# Altium schematic sheet rpi.SchDoc
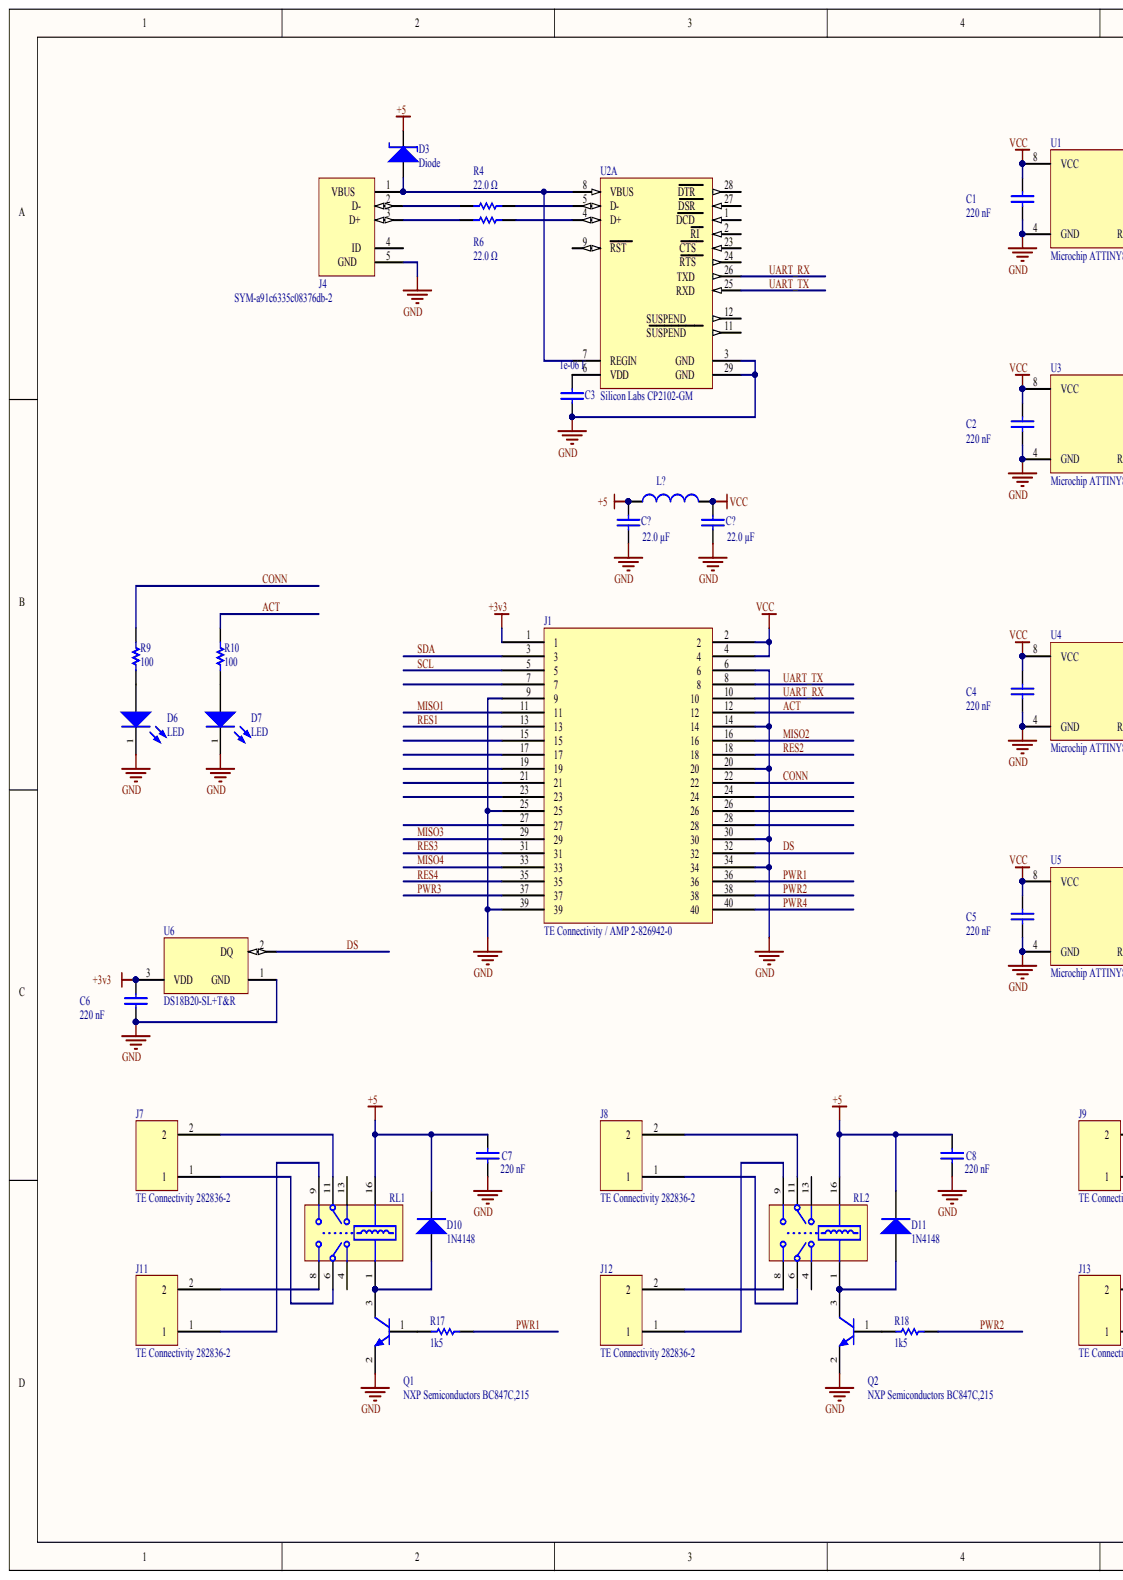
[diagram p0: rpi.SchDoc, left]
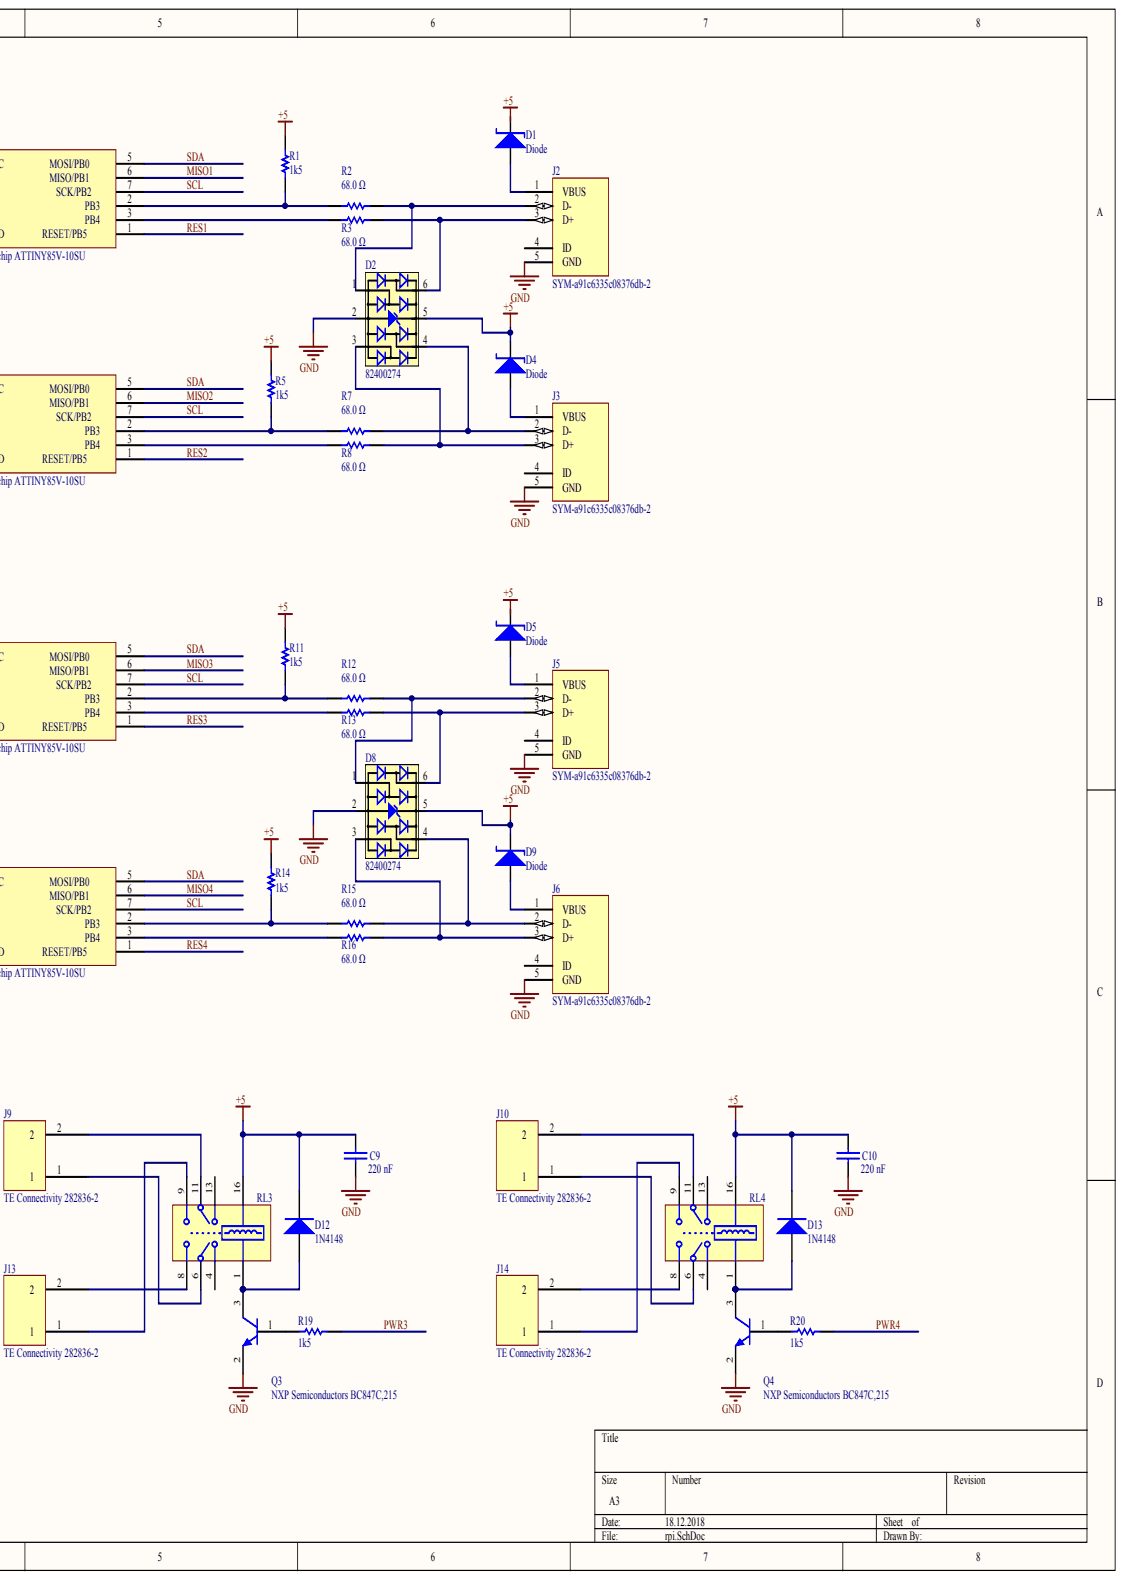
[diagram p1: rpi.SchDoc, right]

## Parts
C1  Capacitance: 220 nF  [0603C_B]  (hidden comment: Murata GRM188R71E224KA88D)
C2  Capacitance: 220 nF  [0603C_B]  (hidden comment: Murata GRM188R71E224KA88D)
C3  Capacitance: 1e-06 F  [CAPC1608X90X35ML10T15]  (hidden comment: Murata GRM188R71C105KA12D)
C4  Capacitance: 220 nF  [0603C_B]  (hidden comment: Murata GRM188R71E224KA88D)
C5  Capacitance: 220 nF  [0603C_B]  (hidden comment: Murata GRM188R71E224KA88D)
C6  Capacitance: 220 nF  [0603C_B]  (hidden comment: Murata GRM188R71E224KA88D)
C7  Capacitance: 220 nF  [0603C_B]  (hidden comment: Murata GRM188R71E224KA88D)
C8  Capacitance: 220 nF  [0603C_B]  (hidden comment: Murata GRM188R71E224KA88D)
C9  Capacitance: 220 nF  [0603C_B]  (hidden comment: Murata GRM188R71E224KA88D)
C10  Capacitance: 220 nF  [0603C_B]  (hidden comment: Murata GRM188R71E224KA88D)
C?  Capacitance: 22.0 µF  [0805_A]  (hidden comment: Murata GRM21BR60J226ME39L)
C?  Capacitance: 22.0 µF  [0805_A]  (hidden comment: Murata GRM21BR60J226ME39L)
D1  Diode  [PCB-vjq2rjjsup9nxnsehq5y-1]
D2  82400274  [SC70-6L]
D3  Diode  [PCB-vjq2rjjsup9nxnsehq5y-1]
D4  Diode  [PCB-vjq2rjjsup9nxnsehq5y-1]
D5  Diode  [PCB-vjq2rjjsup9nxnsehq5y-1]
D6  LED  [PCB-gr2jy36d8nx0qmaftz6p-1]
D7  LED  [PCB-gr2jy36d8nx0qmaftz6p-1]
D8  82400274  [SC70-6L]
D9  Diode  [PCB-vjq2rjjsup9nxnsehq5y-1]
D10  1N4148  [PCB-1N4148W-7-F]
D11  1N4148  [PCB-1N4148W-7-F]
D12  1N4148  [PCB-1N4148W-7-F]
D13  1N4148  [PCB-1N4148W-7-F]
J1  TE Connectivity / AMP 2-826942-0  [PCB-06fujmgdlxhtirs4uei8-1]
J2  SYM-a91c6335c08376db-2  [PCB-c5b7ftra52io2x53bzmw-1]
J3  SYM-a91c6335c08376db-2  [PCB-c5b7ftra52io2x53bzmw-1]
J4  SYM-a91c6335c08376db-2  [PCB-c5b7ftra52io2x53bzmw-1]
J5  SYM-a91c6335c08376db-2  [PCB-c5b7ftra52io2x53bzmw-1]
J6  SYM-a91c6335c08376db-2  [PCB-c5b7ftra52io2x53bzmw-1]
J7  TE Connectivity 282836-2  Manufacturer Part Number: 282836-2  [PCB-1gi00udnwlns1fthuchu-1]
J8  TE Connectivity 282836-2  Manufacturer Part Number: 282836-2  [PCB-1gi00udnwlns1fthuchu-1]
J9  TE Connectivity 282836-2  Manufacturer Part Number: 282836-2  [PCB-1gi00udnwlns1fthuchu-1]
J10  TE Connectivity 282836-2  Manufacturer Part Number: 282836-2  [PCB-1gi00udnwlns1fthuchu-1]
J11  TE Connectivity 282836-2  Manufacturer Part Number: 282836-2  [PCB-1gi00udnwlns1fthuchu-1]
J12  TE Connectivity 282836-2  Manufacturer Part Number: 282836-2  [PCB-1gi00udnwlns1fthuchu-1]
J13  TE Connectivity 282836-2  Manufacturer Part Number: 282836-2  [PCB-1gi00udnwlns1fthuchu-1]
J14  TE Connectivity 282836-2  Manufacturer Part Number: 282836-2  [PCB-1gi00udnwlns1fthuchu-1]
L?  Murata LQM21NN2R2K10D  [PCB-6xavmov8f9jbkmhuz9jz-1]  (value not drawn)
Q1  NXP Semiconductors BC847C,215  [PCB-p8khi1w6gntrmsp9bbyg-1]
Q2  NXP Semiconductors BC847C,215  [PCB-p8khi1w6gntrmsp9bbyg-1]
Q3  NXP Semiconductors BC847C,215  [PCB-p8khi1w6gntrmsp9bbyg-1]
Q4  NXP Semiconductors BC847C,215  [PCB-p8khi1w6gntrmsp9bbyg-1]
R1  1k5  [PCB-9cf7z3ce0dktpf6ltksy-1]
R2  Resistance: 68.0 Ω  [RESC1609X50X30ML10T20]  (hidden comment: Vishay Dale CRCW060368R0FKEA)
R3  Resistance: 68.0 Ω  [RESC1609X50X30ML10T20]  (hidden comment: Vishay Dale CRCW060368R0FKEA)
R4  Resistance: 22.0 Ω  [RESC1609X50X30ML10T20]  (hidden comment: Vishay Dale CRCW060322R0JNEA)
R5  1k5  [PCB-9cf7z3ce0dktpf6ltksy-1]
R6  Resistance: 22.0 Ω  [RESC1609X50X30ML10T20]  (hidden comment: Vishay Dale CRCW060322R0JNEA)
R7  Resistance: 68.0 Ω  [RESC1609X50X30ML10T20]  (hidden comment: Vishay Dale CRCW060368R0FKEA)
R8  Resistance: 68.0 Ω  [RESC1609X50X30ML10T20]  (hidden comment: Vishay Dale CRCW060368R0FKEA)
R9  100  [RESC1609X55X28LL05T18]
R10  100  [RESC1609X55X28LL05T18]
R11  1k5  [PCB-9cf7z3ce0dktpf6ltksy-1]
R12  Resistance: 68.0 Ω  [RESC1609X50X30ML10T20]  (hidden comment: Vishay Dale CRCW060368R0FKEA)
R13  Resistance: 68.0 Ω  [RESC1609X50X30ML10T20]  (hidden comment: Vishay Dale CRCW060368R0FKEA)
R14  1k5  [PCB-9cf7z3ce0dktpf6ltksy-1]
R15  Resistance: 68.0 Ω  [RESC1609X50X30ML10T20]  (hidden comment: Vishay Dale CRCW060368R0FKEA)
R16  Resistance: 68.0 Ω  [RESC1609X50X30ML10T20]  (hidden comment: Vishay Dale CRCW060368R0FKEA)
R17  1k5  [PCB-9cf7z3ce0dktpf6ltksy-1]
R18  1k5  [PCB-9cf7z3ce0dktpf6ltksy-1]
R19  1k5  [PCB-9cf7z3ce0dktpf6ltksy-1]
R20  1k5  [PCB-9cf7z3ce0dktpf6ltksy-1]
RL1  Omron G5V-2-DC12  [PCB-xh0ouwlelej4hns4evo0-1]  (value not drawn)
RL2  Omron G5V-2-DC12  [PCB-xh0ouwlelej4hns4evo0-1]  (value not drawn)
RL3  Omron G5V-2-DC12  [PCB-xh0ouwlelej4hns4evo0-1]  (value not drawn)
RL4  Omron G5V-2-DC12  [PCB-xh0ouwlelej4hns4evo0-1]  (value not drawn)
U1  Microchip ATTINY85V-10SU  Manufacturer Part Number: ATTINY85V-10SU  [SOP8_troughBoard]
U2  Silicon Labs CP2102-GM  Manufacturer Part Number: CP2102-GM  [PCB-r2q4wj0b96jlqp92htuq-1]  (part A)
U3  Microchip ATTINY85V-10SU  Manufacturer Part Number: ATTINY85V-10SU  [SOP8_troughBoard]
U4  Microchip ATTINY85V-10SU  Manufacturer Part Number: ATTINY85V-10SU  [SOP8_troughBoard]
U5  Microchip ATTINY85V-10SU  Manufacturer Part Number: ATTINY85V-10SU  [SOP8_troughBoard]
U6  DS18B20-SL+T&R  [Q3+1]

## Nets
+3V3: C6.1 J1.1 U6.3
+5: C7.1 C8.1 C9.1 C10.1 C?.1 D2.5 D3.2 D4.2 D8.5 D9.2 D10.2 D11.2 D12.2 D13.2 L?.1 R1.2 R5.2 R11.2 R14.2 RL1.16 RL2.16 RL3.16 RL4.16
GND: C1.2 C2.2 C3.1 C4.2 C5.2 C6.2 C7.2 C8.2 C9.2 C10.2 C?.2 D2.2 D6.1 D7.1 D8.2 J1.6 J1.9 J1.14 J1.20 J1.25 J1.30 J1.34 J1.39 J2.5(GND) J3.5(GND) J4.5(GND) J5.5(GND) J6.5(GND) Q1.2 Q2.2 Q3.2 Q4.2 U1.4(GND) U2.3(GND) U2.29(GND) U3.4(GND) U4.4(GND) U5.4(GND) U6.1
VCC: C1.1 C2.1 C4.1 C5.1 C?.1 J1.2 J1.4 L?.2 U1.8(VCC) U3.8(VCC) U4.8(VCC) U5.8(VCC)
ACT: J1.12 R10.2
CONN: J1.22 R9.2
DS: J1.32 U6.2
MISO1: J1.11 U1.6
MISO2: J1.16 U3.6
MISO3: J1.29 U4.6
MISO4: J1.33 U5.6
PWR1: J1.36 R17.1
PWR2: J1.38 R18.1
PWR3: J1.37 R19.1
PWR4: J1.40 R20.1
RES1: J1.13 U1.1
RES2: J1.18 U3.1
RES3: J1.31 U4.1
RES4: J1.35 U5.1
SCL: J1.5 U1.7 U3.7 U4.7 U5.7
SDA: J1.3 U1.5 U3.5 U4.5 U5.5
UART_RX: J1.10 U2.26(TXD)
UART_TX: J1.8 U2.25(RXD)
NetC3_2: C3.2 U2.6(VDD)
NetD1_1: D1.1 J2.1(VBUS)
NetD2_1: D2.1 J2.2(D-) R2.2
NetD2_3: D2.3 J3.3(D+) R8.2
NetD2_4: D2.4 J3.2(D-) R7.2
NetD2_6: D2.6 J2.3(D+) R3.2
NetD3_1: D3.1 J4.1(VBUS) U2.7(REGIN) U2.8(VBUS)
NetD4_1: D4.1 J3.1(VBUS)
NetD5_1: D5.1 J5.1(VBUS)
NetD6_2: D6.2 R9.1
NetD7_2: D7.2 R10.1
NetD8_1: D8.1 J5.2(D-) R12.2
NetD8_3: D8.3 J6.3(D+) R16.2
NetD8_4: D8.4 J6.2(D-) R15.2
NetD8_6: D8.6 J5.3(D+) R13.2
NetD9_1: D9.1 J6.1(VBUS)
NetD10_1: D10.1 Q1.3 RL1.1
NetD11_1: D11.1 Q2.3 RL2.1
NetD12_1: D12.1 Q3.3 RL3.1
NetD13_1: D13.1 Q4.3 RL4.1
NetJ4_2: J4.2(D-) R4.1
NetJ4_3: J4.3(D+) R6.1
NetJ7_1: J7.1 RL1.6
NetJ7_2: J7.2 RL1.11
NetJ8_1: J8.1 RL2.6
NetJ8_2: J8.2 RL2.11
NetJ9_1: J9.1 RL3.6
NetJ9_2: J9.2 RL3.11
NetJ10_1: J10.1 RL4.6
NetJ10_2: J10.2 RL4.11
NetJ11_1: J11.1 RL1.9
NetJ11_2: J11.2 RL1.8
NetJ12_1: J12.1 RL2.9
NetJ12_2: J12.2 RL2.8
NetJ13_1: J13.1 RL3.9
NetJ13_2: J13.2 RL3.8
NetJ14_1: J14.1 RL4.9
NetJ14_2: J14.2 RL4.8
NetQ1_1: Q1.1 R17.2
NetQ2_1: Q2.1 R18.2
NetQ3_1: Q3.1 R19.2
NetQ4_1: Q4.1 R20.2
NetR1_1: R1.1 R2.1 U1.2(PB3)
NetR3_1: R3.1 U1.3(PB4)
NetR4_2: R4.2 U2.5(D-)
NetR5_1: R5.1 R7.1 U3.2(PB3)
NetR6_2: R6.2 U2.4(D+)
NetR8_1: R8.1 U3.3(PB4)
NetR11_1: R11.1 R12.1 U4.2(PB3)
NetR13_1: R13.1 U4.3(PB4)
NetR14_1: R14.1 R15.1 U5.2(PB3)
NetR16_1: R16.1 U5.3(PB4)

Not connected: D1 2; D5 2; J1 7 15 17 19 21 23 24 26 27 28; J2 4(ID); J3 4(ID); J4 4(ID); J5 4(ID); J6 4(ID); RL1 4 13; RL2 4 13; RL3 4 13; RL4 4 13; U2 1(DCD) 2(RI) 9(RST) 11 12 23(CTS) 24(RTS) 27(DSR) 28(DTR)

## Library data of the parts
C1 C2 C4-C10 = CMP-19299718-5: 0603 0.22 uF 25 V ±10% Tolerance X7R SMT Multilayer Ceramic Capacitor; Case/Package (SI): 1608; Lead-Free Status: Lead Free; Voltage Rating (DC): 25.0 V; Capacitance Tolerance: ±10%; Case/Package: 0603, 1608; Component Kind: Standard; Dielectric Characteristic: X7R; Library Name: CommunityVault; Lifecycle Status: Active; Manufacturer Part Number: GRM188R71E224KA88D; Mounting Style: Surface Mount; Number of Pins: 2; OctopartID: 915b5852b5104e9c; Octopart Url: http://octopart.com/grm188r71e224ka88d-murata-196909; Packaging: Cut Tape (CT), Tape & Reel (TR); REACH SVHC Compliance: No SVHC; RoHS: Compliant; Seller Landing  Url: https://octopart-clicks.com/click/track?country=US&ak=8157f166&sig=0b4b672&sid=1106&ppid=196909&vpid=21236095&ct=offers; Size-Height: 800 µm; Size-Length: 1.60 mm; Size-Thickness: 800 µm; Size-Width: 800 µm
C3 = CMP-121260-3: CAP CER 1UF 16V 10% X7R 0603; Case/Package (SI): 1608; Lead-Free Status: Lead Free; Voltage Rating (DC): 16.0 V; Capacitance Tolerance: ±10%; Component Kind: Standard; Dielectric Characteristic: X7R; Library Name: CommunityVault; Lifecycle Status: Active; Manufacturer Part Number: GRM188R71C105KA12D; Mounting Style: Surface Mount; Number of Pins: 2; OctopartID: 978b5e82b1a1484c; Octopart Url: https://octopart.com/grm188r71c105ka12d-murata-197452; REACH SVHC Compliance: No SVHC; RoHS: Compliant; Size-Height: 0.0008 m; Size-Length: 0.0016 m; Size-Thickness: 0.0008 m; Size-Width: 0.0008 m
C? C? = CMP-19299736-5: Multilayer Ceramic Capacitors MLCC Surface Mount 0805 22uF 6.3 Volts X5R 20%; Voltage Rating (DC): 6.30 V; Component Kind: Standard; Library Name: CommunityVault; Number of Pins: 2; Packaging: Cut Tape (CT), Tape & Reel (TR)
D1 D3-D5 D9 = CMP-fb98311b90f6245f-5: Diode Schottky SOD-123; Component Kind: Standard; Library Name: CommunityVault; Octopart URL: http://octopart.com/ss14fl-fairchild+semiconductor-59330056
D2 D8 = CMP-1038096-2: TVS Diode WE-TVS-HS, VRWM=5.0V; Component Kind: Standard; Library Name: CommunityVault; Manufacturer Part Number: 82400274; Number of Pins: 6; OctopartID: 26cceed38cbbf90b; Octopart Url: https://octopart.com/82400274-w%C3%BCrth+elektronik-18190097; REACH SVHC Compliance: No SVHC; RoHS: Compliant
D6 D7 = CMP-1544855-6: LED; SMD; 0603; red; 18-180mcd; 1.6x0.8x0.8mm; 130°; 1.6÷2.4V; 20mA; Manufacturer Part Number: LTST-C190KRKT; Case/Package (SI): 1608; Forward Voltage: 2.00 V; Lead-Free Status: Lead Free; Lens Type: Non-Diffused; Luminous Intensity: 54.0 mcd; Wavelength: 639 nm; Case/Package: 0603; Color: Red; Component Kind: Standard; Library Name: CommunityVault; Mounting Style: Surface Mount, Vertical; OctopartID: 1e52d7505f31c195; Operating Temperature: 85.0 °C (max); Packaging: Tape & Reel (TR), Cut Tape (CT); RoHS: Compliant; Size-Length: 1.60 mm; Size-Width: 800 µm; Viewing Angle: 130 °
D10-D13 = CMP-791fb3587d52a6d8-18: Fast Switching Diode, 100V, 400mW, Surface Mount, SOD-123; Manufacturer Part Number: 1N4148W-7-F; Current Rating: 150 mA; Output Current: 300mA (max); Case/Package: SOD-123; Component Kind: Standard; Datasheet PDF: https://www.diodes.com/assets/Datasheets/ds30086.pdf; Library Name: CommunityVault; Manufacturer PN: 1N4148W-7-F; Mounting Style: Surface Mount; OctopartID: 791fb3587d52a6d8; Octopart URL: https://octopart.com/1n4148w-7-f-diodes+inc.-55386411; RoHS: Compliant; Voltage Rating (DC): 100V
J1 = CMP-ccc407be18a11303-7: Conn Unshrouded Header HDR 40 POS 2.54mm Solder ST Thru-Hole Carton; Component Kind: Standard; Library Name: CommunityVault
J2-J6 = CMP-20438115-17: Horizontal SMT Type B Version 2.0 MicroUSB Connector Socket; 30 V ac; 3A WR-COM; Component Kind: Standard; Library Name: CommunityVault; RoHS: Compliant
J7-J14 = CMP-369bfdc835bbe95c-11: Connector, Terminal Block; Wire Receptacle; 2 Pos.; 16-30 AWG; 0.197 in. CL; Sid; Current Rating: 13.5 A; ELV Compliant: Compliant; Lead-Free Status: Lead Free; Number of Contacts: 2; Pin Pitch: 5.00 mm; Voltage Rating (AC): 250 V; Wire Gauge: 15.0 AWG; Color: Green; Component Kind: Standard; Contact Material: Brass; Contact Plating: Tin; Flammability Rating: UL 94 V-0; Housing Color: Green; Housing Material: Nylon 6/6; Insulation Material: Nylon; Library Name: CommunityVault; Lifecycle Status: Active; Mounting Style: Screw, PC Board, PCB; Number of Positions: 2; OctopartID: 369bfdc835bbe95c; Octopart URL: https://octopart.com/282836-2-te+connectivity-39396934; Orientation: Right Angle; Packaging: Bulk; REACH SVHC Compliance: No SVHC
L? = CMP-8d95449a41975252-2: Ind General Purpose Chip Shielded Multi-Layer 2.2uH 10% 10MHz 45Q-Factor Ferrite 30mA 0805 Paper T/R; Case/Package (SI): 2012; Inductance: 2.2e-06 H; Lead-Free Status: Lead Free; Self Resonant Frequency: 71000000.0 Hz; Shielding: Yes; Case/Package: 0805, 2012; Component Kind: Standard; Library Name: CommunityVault; Lifecycle Status: Active; Manufacturer Part Number: LQM21NN2R2K10D; Mounting Style: Surface Mount; OctopartID: 8d95449a41975252; Octopart URL: http://octopart.com/lqm21nn2r2k10d-murata-387428; Packaging: Reel; REACH SVHC Compliance: No SVHC; RoHS: Compliant; Size-Height: 0.00085 m; Size-Length: 0.002 m; Size-Width: 0.00125 m
Q1-Q4 = CMP-aaad63386f2b756a-2: BC847 series - 45 V, 100 mA NPN general-purpose transistors; Component Kind: Standard; Library Name: CommunityVault
R1 R5 R11 R14 R17-R20 = CMP-4e96865603629151-1: Res Thick Film 0603 1.5K Ohm 1% 0.25W(1/4W) }100ppm/C Molded SMD Automotive Punched T/R; Case/Package (SI): 1608; Lead-Free Status: Lead Free; Component Kind: Standard; Library Name: CommunityVault
R2 R3 R7 R8 R12 R13 R15 R16 = CMP-1866999-2: RES SMD 68 OHM 1% 1/10W 0603; Lead-Free Status: Lead Free; Power Rating: 0.1 W; Voltage Rating (DC): 75.0 V; Case/Package: 0603; Component Kind: Standard; Library Name: CommunityVault; Manufacturer Part Number: CRCW060368R0FKEA; Mounting Style: Surface Mount; Number of Pins: 2; OctopartID: 47348c61196d98f3; Octopart Url: https://octopart.com/crcw060368r0fkea-vishay-39811903; REACH SVHC Compliance: No SVHC; Resistance Tolerance: ±1%; RoHS: Compliant; Size-Height: 0.00045 m; Size-Length: 0.00155 m; Size-Width: 0.00085 m
R4 R6 = CMP-1856016-2: RES SMD 22 OHM 5% 1/10W 0603; Lead-Free Status: Lead Free; Power Rating: 0.1 W; Temperature Coefficient: 200.0 ppm/°C; Voltage Rating (DC): 75.0 V; Case/Package: 0603; Component Kind: Standard; Library Name: CommunityVault; Manufacturer Part Number: CRCW060322R0JNEA; Mounting Style: Surface Mount; Number of Pins: 2; OctopartID: 4df186a412bb9236; Octopart Url: https://octopart.com/crcw060322r0jnea-vishay-39811628; REACH SVHC Compliance: No SVHC; Resistance Tolerance: ±5%; RoHS: Compliant; Size-Height: 0.00045 m; Size-Length: 0.00155 m; Size-Width: 0.00085 m
R9 R10 = CMP-b61a7db41c6e69dd-1: Precision Thin Film Smnt Resistor 0603 Case 100Ohm +/-0.1% 0.1W +/-25ppm/degC; Manufacturer: Vishay; Manufacturer Part Number: MCT0603MD1000BP100; Power Rating: 125 mW; Resistance: 100 Ω; Temperature Coefficient: -25.0 ppm/°C to 25.0 ppm/°C; Voltage Rating (DC): 75.0 V; Component Kind: Standard; Library Name: CommunityVault; Mounting Style: Surface Mount; Number of Pins: 2; OctopartID: b61a7db41c6e69dd; Operating Temperature: 155 °C (max); RoHS: Compliant
RL1-RL4 = CMP-0896c19c22d9d751-8: Electromechanical Relay Double Pole Double Throw 2A 12 Volt 288Ohm Through Hole; Component Kind: Standard; Library Name: CommunityVault
U1 U3-U5 = CMP-2386867-13: ATtiny Series 10 MHz 8 KB Flash 512 B SRAM 8-Bit Microcontroller - SOIC-8; Access Time: 10.0 µs; Core Architecture: AVR; FLASH Memory Size: 8192 B; Lead-Free Status: Lead Free; Number of I/O Pins: 6; RAM Memory Size: 512 B; Supply Voltage (DC): 5.00 V, 5.50 V (max); Case/Package: SOIC; Component Kind: Standard; Library Name: CommunityVault; Mounting Style: Surface Mount; Number of Pins: 8; OctopartID: 3167fa36ec9feea0; Octopart URL: http://octopart.com/attiny85v-10su-microchip-39581854; REACH SVHC Compliance: No SVHC; RoHS: Compliant
U2 = CMP-967561-7: Microcontroller Solutions - Interface Products USB to UART Bdg Chip; Data Rate: 1000000.0 bps; Lead-Free Status: Lead Free; Supply Current: 0.1 A; Supply Voltage (DC): V; Case/Package: MLP; Component Kind: Standard; Library Name: CommunityVault; Mounting Style: Surface Mount; Number of Pins: 28; OctopartID: 84694d644fd15bae; Octopart URL: http://octopart.com/cp2102-gm-silicon+labs-519902; Packaging: Bulk; RoHS: Compliant
U6 = CMP-1468669-2: Programmable Resolution Digital Thermometer, 1-Wire Interface, ±0.5°C Accuracy, 3-Pin TO92, -55°C to +125°C, 2000 Tape and Reel, Pb-Free; Component Kind: Standard; Library Name: CommunityVault; Lifecycle Status: Not Listed by Manufacturer; Manufacturer Part Number: DS18B20-SL+T&R; OctopartID: d91c129b811206db; Octopart Url: https://octopart.com/ds18b20-sl%2Bt%26r-maxim+integrated-42827112
